# Altium schematic sheet Arriquitaun.SchDoc(Arriquitaun)
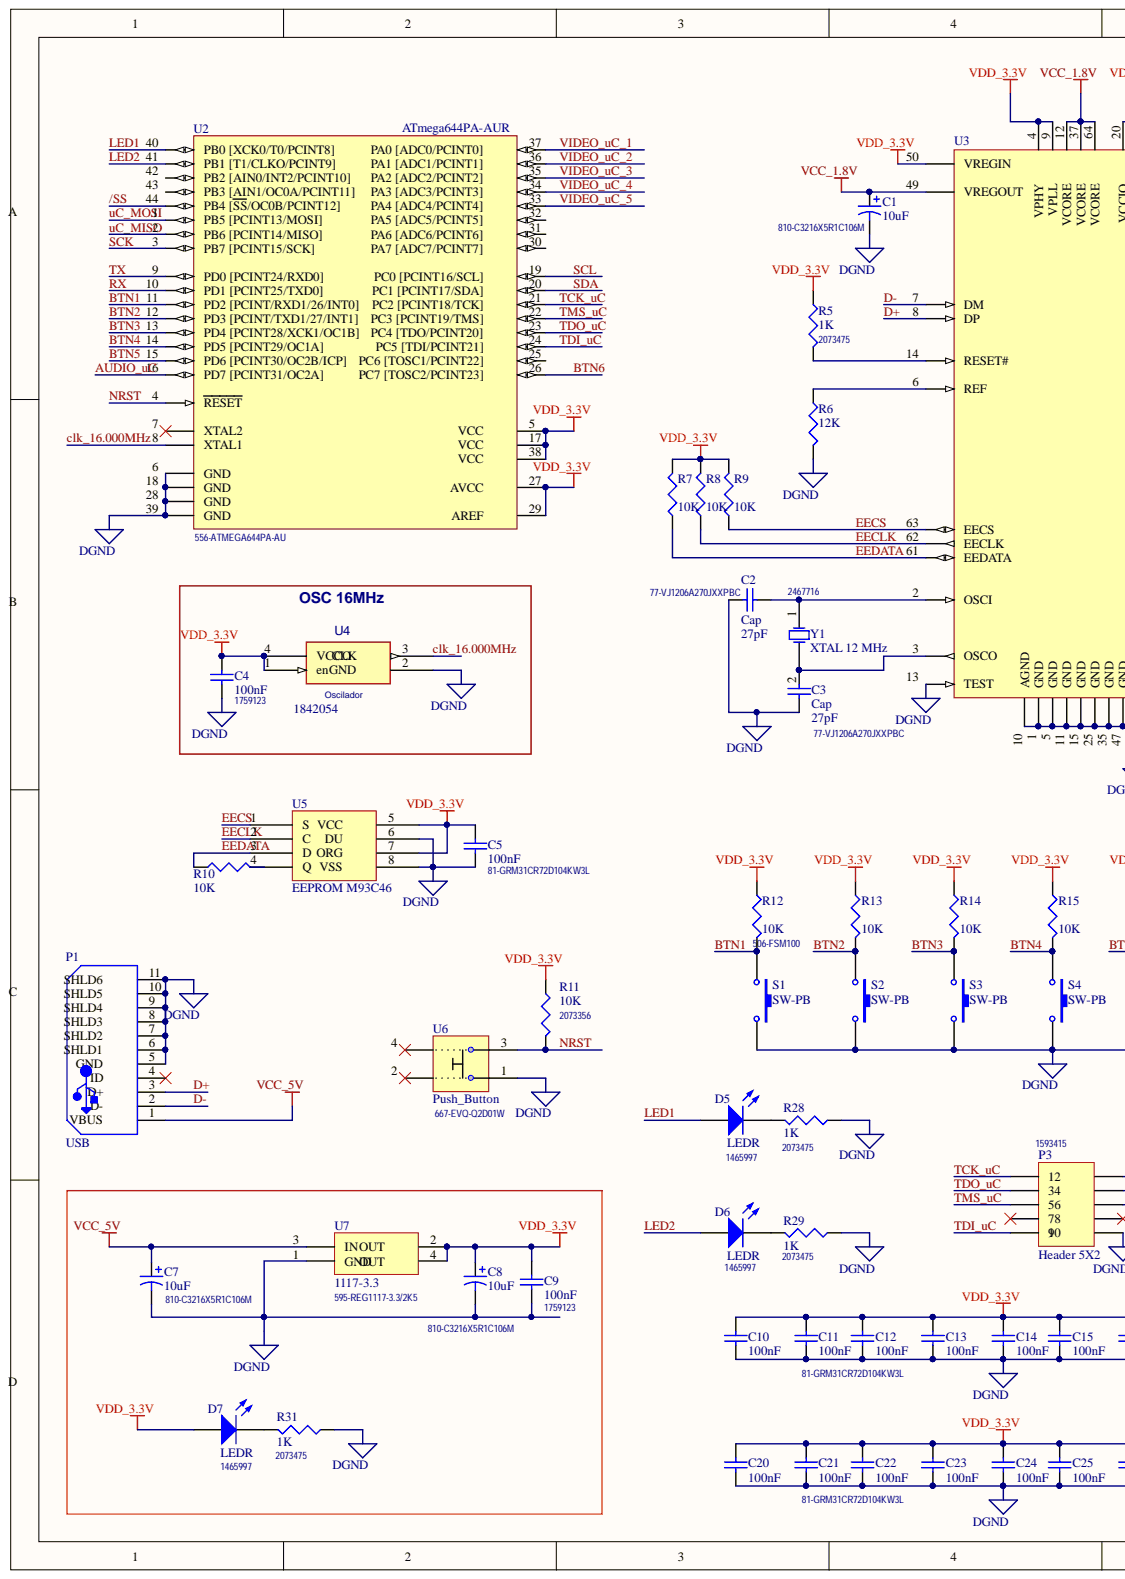
[diagram p0: Arriquitaun.SchDoc, left]
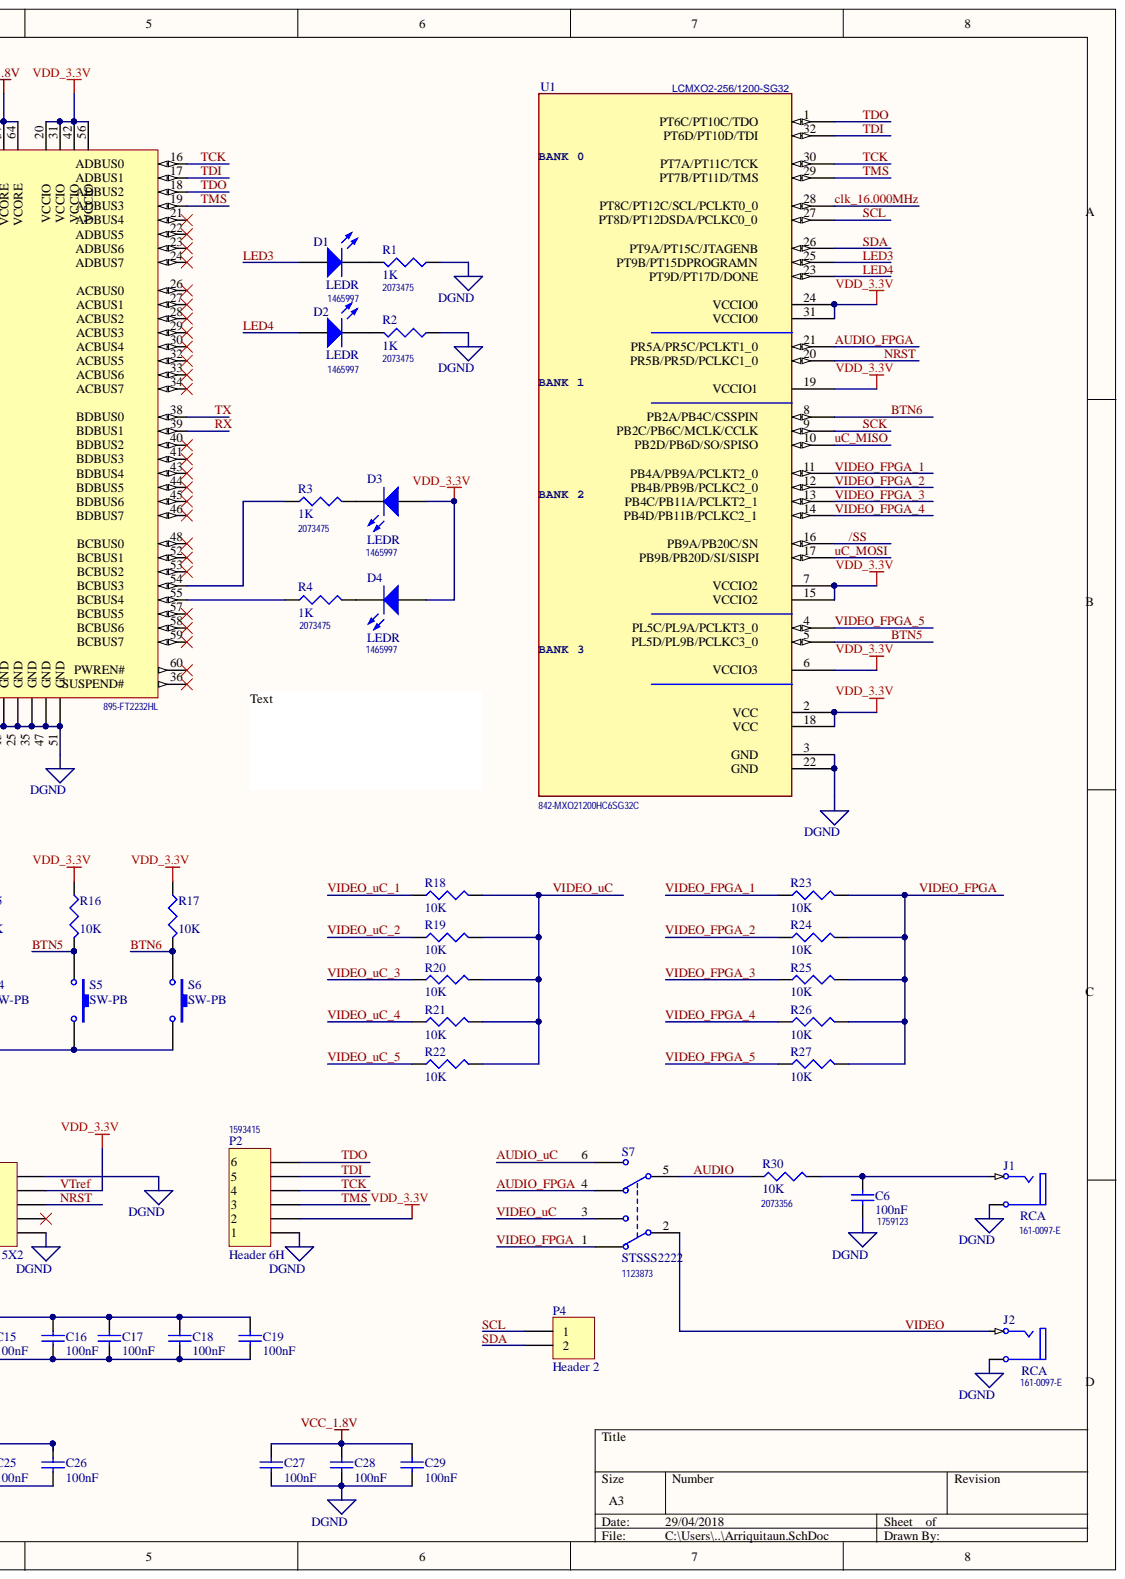
[diagram p1: Arriquitaun.SchDoc, right]

## Parts
C1  value 10uF  Supplier part number 1: 810-C3216X5R1C106M  [C1206]  (hidden comment: Cap Pol1)
C2  Cap  value 27pF  Supplier Part Number 1: 77-VJ1206A270JXXPBC  [C1206]
C3  Cap  value 27pF  Supplier Part Number 1: 77-VJ1206A270JXXPBC  [C1206]
C4  value 100nF  Supplier part number 1: 1759123  [C1206]  (hidden comment: Cap Semi)
C5  value 100nF  Supplier Part Number 1: 81-GRM31CR72D104KW3L  [C1206]  (hidden comment: Cap Semi)
C6  value 100nF  Supplier part number 1: 1759123  [C1206]  (hidden comment: Cap Semi)
C7  value 10uF  Supplier Part Number 1: 810-C3216X5R1C106M  [C1206]  (hidden comment: Cap Pol1)
C8  value 10uF  Supplier part number 1: 810-C3216X5R1C106M  [C1206]  (hidden comment: Cap Pol1)
C9  value 100nF  Supplier part number 1: 1759123  [C1206]  (hidden comment: Cap Semi)
C10  value 100nF  [C1206]  (hidden comment: Cap Semi)
C11  value 100nF  Supplier Part Number 1: 81-GRM31CR72D104KW3L  [C1206]  (hidden comment: Cap Semi)
C12  value 100nF  Supplier Part Number 1: 81-GRM31CR72D104KW3L  [C1206]  (hidden comment: Cap Semi)
C13  value 100nF  [C1206]  (hidden comment: Cap Semi)
C14  value 100nF  [C1206]  (hidden comment: Cap Semi)
C15  value 100nF  [C1206]  (hidden comment: Cap Semi)
C16  value 100nF  [C1206]  (hidden comment: Cap Semi)
C17  value 100nF  [C1206]  (hidden comment: Cap Semi)
C18  value 100nF  [C1206]  (hidden comment: Cap Semi)
C19  value 100nF  [C1206]  (hidden comment: Cap Semi)
C20  value 100nF  [C1206]  (hidden comment: Cap Semi)
C21  value 100nF  Supplier Part Number 1: 81-GRM31CR72D104KW3L  [C1206]  (hidden comment: Cap Semi)
C22  value 100nF  Supplier Part Number 1: 81-GRM31CR72D104KW3L  [C1206]  (hidden comment: Cap Semi)
C23  value 100nF  [C1206]  (hidden comment: Cap Semi)
C24  value 100nF  [C1206]  (hidden comment: Cap Semi)
C25  value 100nF  [C1206]  (hidden comment: Cap Semi)
C26  value 100nF  [C1206]  (hidden comment: Cap Semi)
C27  value 100nF  [C1206]  (hidden comment: Cap Semi)
C28  value 100nF  [C1206]  (hidden comment: Cap Semi)
C29  value 100nF  [C1206]  (hidden comment: Cap Semi)
D1  LEDR  [1206 - LED]
D2  LEDR  Supplier Part Number 1: 1465997  [1206 - LED]
D3  LEDR  Supplier Part Number 1: 1465997  [1206 - LED]
D4  LEDR  Supplier Part Number 1: 1465997  [1206 - LED]
D5  LEDR  Supplier Part Number 1: 1465997  [1206 - LED]
D6  LEDR  Supplier Part Number 1: 1465997  [1206 - LED]
D7  LEDR  Supplier Part Number 1: 1465997  [1206 - LED]
J1  RCA  Supplier Part Number 1: 161-0097-E  [161]
J2  RCA  Supplier Part Number 1: 161-0097-E  [161]
P1  USB  [USB-MICRO-B_V]
P2  Header 6H  Supplier Part Number 1: 1593415  [HDR1X6H]
P3  Header 5X2  Supplier Part Number 1: 1593415  [HDR2X5]
P4  Header 2  [HDR1X2]
R1  value 1K  Supplier Part Number 1: 2073475  [c1206]  (hidden comment: Res3)
R2  value 1K  Supplier Part Number 1: 2073475  [c1206]  (hidden comment: Res3)
R3  value 1K  Supplier Part Number 1: 2073475  [c1206]  (hidden comment: Res3)
R4  value 1K  Supplier Part Number 1: 2073475  [c1206]  (hidden comment: Res3)
R5  value 1K  Supplier Part Number 1: 2073475  [c1206]  (hidden comment: Res3)
R6  value 12K  [c1206]  (hidden comment: Res3)
R7  value 10K  [c1206]  (hidden comment: Res3)
R8  value 10K  [c1206]  (hidden comment: Res3)
R9  value 10K  [c1206]  (hidden comment: Res3)
R10  value 10K  [c1206]  (hidden comment: Res3)
R11  value 10K  Supplier Part Number 1: 2073356  [c1206]  (hidden comment: Res3)
R12  value 10K  [c1206]  (hidden comment: Res3)
R13  value 10K  [c1206]  (hidden comment: Res3)
R14  value 10K  [c1206]  (hidden comment: Res3)
R15  value 10K  [c1206]  (hidden comment: Res3)
R16  value 10K  [c1206]  (hidden comment: Res3)
R17  value 10K  [c1206]  (hidden comment: Res3)
R18  value 10K  [c1206]  (hidden comment: Res3)
R19  value 10K  [c1206]  (hidden comment: Res3)
R20  value 10K  [c1206]  (hidden comment: Res3)
R21  value 10K  [c1206]  (hidden comment: Res3)
R22  value 10K  [c1206]  (hidden comment: Res3)
R23  value 10K  [c1206]  (hidden comment: Res3)
R24  value 10K  [c1206]  (hidden comment: Res3)
R25  value 10K  [c1206]  (hidden comment: Res3)
R26  value 10K  [c1206]  (hidden comment: Res3)
R27  value 10K  [c1206]  (hidden comment: Res3)
R28  value 1K  Supplier Part Number 1: 2073475  [c1206]  (hidden comment: Res3)
R29  value 1K  Supplier Part Number 1: 2073475  [c1206]  (hidden comment: Res3)
R30  value 10K  Supplier Part Number 1: 2073356  [c1206]  (hidden comment: Res3)
R31  value 1K  Supplier Part Number 1: 2073475  [c1206]  (hidden comment: Res3)
S1  SW-PB  Supplier Part Number 1: 506-FSM100  [FSM100]
S2  SW-PB  [FSM100]
S3  SW-PB  [FSM100]
S4  SW-PB  [FSM100]
S5  SW-PB  [FSM100]
S6  SW-PB  [FSM100]
S7  STSSS2222  Supplier Part Number 1: 1123873  [SWITCH]
U1  LCMXO2-256/1200-SG32  Supplier Part Number 1: 842-MXO21200HC6SG32C  [QFN32 5x5mm SOT617-3]
U2  ATmega644PA-AUR  Supplier Part Number 1: 556-ATMEGA644PA-AU  [44A_N]
U3  Supplier Part Number 1: 895-FT2232HL  [LQFP-64_N]  (hidden comment: FT2232HL-Tray)
U4  Oscilador  Supplier Part Number 1: 1842054  [OSCILADOR]
U5  EEPROM M93C46
U6  Push_Button  Supplier Part Number 1: 667-EVQ-Q2D01W  [PUSHBUTTON]
U7  1117-3.3  Supplier Part Number 1: 595-REG1117-3.3/2K5  [ZZ311]
Y1  XTAL 12 MHz  Supplier Part Number 1: 2467716  [CRYSTAL_HC49-US-SM]

## Nets
DGND: C1.2 C2.2 C3.1 C4.1 C5.1 C6.1 C7.2 C8.2 C9.1 C10.1 C11.1 C12.1 C13.1 C14.1 C15.1 C16.1 C17.1 C18.1 C19.1 C20.1 C21.1 C22.1 C23.1 C24.1 C25.1 C26.1 C27.1 C28.1 C29.1 J1.1A J2.1A P1.5 P1.6 P1.7 P1.8 P1.9 P1.10 P1.11 P2.1 P3.2 P3.10 R1.1 R2.1 R6.2 R28.1 R29.1 R31.1 S1.2 S2.2 S3.2 S4.2 S5.2 S6.2 U1.3 U1.22 U2.6(GND) U2.18(GND) U2.28(GND) U2.39(GND) U3.1(GND) U3.5(GND) U3.10(AGND) U3.11(GND) U3.13(TEST) U3.15(GND) U3.25(GND) U3.35(GND) U3.47(GND) U3.51(GND) U4.2 U5.6 U5.8 U6.1 U7.1(GND)
VCC_1.8V: C1.1 C27.2 C28.2 C29.2 U3.12 U3.37 U3.49(VREGOUT) U3.64
VCC_5V: C7.1 P1.1 U7.3(IN_OUT)
/SS: U1.16 U2.44(PB4_[SS/OC0B/PCINT12])
AUDIO: R30.1 S7.5
AUDIO_FPGA: S7.4 U1.21
AUDIO_UC: S7.6 U2.16(PD7_[PCINT31/OC2A])
BTN1: R12.2 S1.1 U2.11(PD2_[PCINT/RXD1/26/INT0])
BTN2: R13.2 S2.1 U2.12(PD3_[PCINT/TXD1/27/INT1])
BTN3: R14.2 S3.1 U2.13(PD4_[PCINT28/XCK1/OC1B])
BTN4: R15.2 S4.1 U2.14(PD5_[PCINT29/OC1A])
BTN5: R16.2 S5.1 U1.5 U2.15(PD6_[PCINT30/OC2B/ICP])
BTN6: R17.2 S6.1 U1.8 U2.26
CLK_16.000MHZ: U1.28 U2.8(XTAL1) U4.3
D+: P1.3 U3.8(DP)
D-: P1.2 U3.7(DM)
EECLK: R8.1 U3.62(EECLK) U5.2(C)
EECS: R9.1 U3.63(EECS) U5.1(S)
EEDATA: R7.1 R10.1 U3.61(EEDATA) U5.3(D)
LED1: D5.1 U2.40(PB0_[XCK0/T0/PCINT8])
LED2: D6.1 U2.41(PB1_[T1/CLKO/PCINT9])
LED3: D1.1 U1.25
LED4: D2.1 U1.23
NRST: P3.6 R11.2 U1.20 U2.4(RESET) U6.3
RX: U2.10(PD1_[PCINT25/TXD0]) U3.39
SCK: U1.9 U2.3(PB7_[PCINT15/SCK])
SCL: P4.1 U1.27 U2.19
SDA: P4.2 U1.26 U2.20
TCK: P2.4 U1.30 U3.16
TCK_UC: P3.1 U2.21
TDI: P2.5 U1.32 U3.17
TDI_UC: P3.9 U2.24
TDO: P2.6 U1.1 U3.18
TDO_UC: P3.3 U2.23
TMS: P2.3 U1.29 U3.19
TMS_UC: P3.5 U2.22
TX: U2.9(PD0_[PCINT24/RXD0]) U3.38
UC_MISO: U1.10 U2.2(PB6_[PCINT14/MISO])
UC_MOSI: U1.17 U2.1(PB5_[PCINT13/MOSI])
VIDEO: J2.2B S7.2
VIDEO_FPGA: R23.2 R24.2 R25.2 R26.2 R27.2 S7.1
VIDEO_FPGA_1: R23.1 U1.11
VIDEO_FPGA_2: R24.1 U1.12
VIDEO_FPGA_3: R25.1 U1.13
VIDEO_FPGA_4: R26.1 U1.14
VIDEO_FPGA_5: R27.1 U1.4
VIDEO_UC: R18.2 R19.2 R20.2 R21.2 R22.2 S7.3
VIDEO_UC_1: R18.1 U2.37
VIDEO_UC_2: R19.1 U2.36
VIDEO_UC_3: R20.1 U2.35
VIDEO_UC_4: R21.1 U2.34
VIDEO_UC_5: R22.1 U2.33
VTREF: C4.2 C5.2 C8.1 C9.2 C10.2 C11.2 C12.2 C13.2 C14.2 C15.2 C16.2 C17.2 C18.2 C19.2 C20.2 C21.2 C22.2 C23.2 C24.2 C25.2 C26.2 D3.1 D4.1 D7.1 P2.2 P3.4 R5.1 R7.2 R8.2 R9.2 R11.1 R12.1 R13.1 R14.1 R15.1 R16.1 R17.1 U1.2 U1.6 U1.7 U1.15 U1.18 U1.19 U1.24 U1.31 U2.5 U2.17 U2.27 U2.29 U2.38 U3.4 U3.9 U3.20 U3.31 U3.42 U3.50(VREGIN) U3.56 U4.1(en_GND) U4.4(VCCO) U5.5 U5.7 U7.2 U7.4
NetC2_1: C2.1 U3.2(OSCI) Y1.1
NetC3_2: C3.2 U3.3(OSCO) Y1.2
NetC6_2: C6.2 J1.2B R30.2
NetD1_2: D1.2 R1.2
NetD2_2: D2.2 R2.2
NetD3_2: D3.2 R3.2
NetD4_2: D4.2 R4.2
NetD5_2: D5.2 R28.2
NetD6_2: D6.2 R29.2
NetD7_2: D7.2 R31.2
NetR3_1: R3.1 U3.54
NetR4_1: R4.1 U3.55
NetR5_2: R5.2 U3.14(RESET#)
NetR6_1: R6.1 U3.6(REF)
NetR10_2: R10.2 U5.4(Q)

Not connected: P1 4; P3 7 8; U2 7(XTAL2) 25 30 31 32 42(PB2_[AIN0/INT2/PCINT10]) 43(PB3_[AIN1/OC0A/PCINT11]); U3 21 22 23 24 26 27 28 29 30 32 33 34 36 40 41 43 44 45 46 48 52 53 57 58 59 60; U6 2 4

## Library data of the parts
C1 C7 C8 = Cap Pol1: Polarized Capacitor (Radial); Component Kind: Standard; LatestRevisionDate: 17-Jul-2002; LatestRevisionNote: Re-released for DXP Platform.; PackageDescription: Polarized Capacitor (Radial); 2 Leads; PackageReference: RB7.6-15; Published: 8-Jun-2000; Signal Integrity: Cap Pol; Simulation: CAP; Supplier 1: Mouser; Library Name: DCRC_Remote.SCHLIB; Publisher: Altium Limited
  C7: Supplier part number 1: 810-C3216X5R1C106M
C2 C3 = Cap: Capacitor; Component Kind: Standard; LatestRevisionDate: 17-Jul-2002; LatestRevisionNote: Re-released for DXP Platform.; Library Name: Miscellaneous Devices.IntLib; PackageDescription: Radial Cap, Thru-Hole; 2 Leads; 0.3 in Pin Spacing; PackageReference: RAD-0.3; Published: 8-Jun-2000; Signal Integrity: Cap; Simulation: CAP; Supplier 1: Mouser; Publisher: Altium Limited
C4-C6 C9-C29 = Cap Semi: Capacitor (Semiconductor SIM Model); Component Kind: Standard; LatestRevisionDate: 29-May-2009; LatestRevisionNote: IPC-7351 Footprint Added.; PackageDescription: Chip Capacitor; PackageReference: C1206; Published: 8-Jun-2000; Signal Integrity: Cap Semi; Simulation: CAP; Library Name: DCRC_Remote.SCHLIB; Publisher: Altium Limited
  C4 C6 C9: Supplier 1: Farnell
  C5 C11 C12 C21 C22: Supplier 1: Mouser
  C10 C13-C20 C23-C29: Supplier 1: Mouser; Supplier Part Number 1: 81-GRM31CR72D104KW3L
D1-D7 = LED2: Typical RED, GREEN, YELLOW, AMBER GaAs LED; Component Kind: Standard; LatestRevisionDate: 17-Jul-2002; LatestRevisionNote: Re-released for DXP Platform.; PackageDescription: SM LED; 2 Flat Leads; PackageReference: 3.2X1.6X1.1; PackageVersion: Feb-2002; Published: 8-Jun-2000; Simulation: LED2; Supplier 1: Farnell; Library Name: DCRC_Remote.SCHLIB; Publisher: Altium Limited
  D1: Supplier Part Number 1: 1465997
J1 J2 = RCA: RCA Phono Jack, Right Angle, Thru-Hole, Snap-In; DrillAndSlotSize: Corresponds to nominal value.; PCB_Layout: Complies with manufacturer's recommendation.; Component Kind: Standard; ComponentLink1Description: Manufacturer Link; ComponentLink1URL: http://www.mouser.com/kobiconn/; DatasheetDocument: 7-Jul-2000; LatestRevisionDate: 15-Jul-2004; LatestRevisionNote: Component Replaced.; Library Name: Miscellaneous Connectors.IntLib; PackageDocument: 7-Jul-2000; PackageReference: 161; Published: 15-Jul-2004; Signal Integrity: RCA; Supplier 1: Mouser; Publisher: Altium Limited
P2 = Header 6H: Header, 6-Pin, Right Angle; Component Kind: Standard; LatestRevisionDate: 17-Jul-2002; LatestRevisionNote: Re-released for DXP Platform.; Library Name: Miscellaneous Connectors.IntLib; Signal Integrity: Connector; Supplier 1: Farnell; Publisher: Altium Limited
P3 = Header 5X2: Header, 5-Pin, Dual row; Component Kind: Standard; LatestRevisionDate: 17-Jul-2002; LatestRevisionNote: Re-released for DXP Platform.; Library Name: Miscellaneous Connectors.IntLib; Signal Integrity: Connector; Supplier 1: Farnell; Publisher: Altium Limited
P4 = Header 2: Header, 2-Pin; Component Kind: Standard; LatestRevisionDate: 17-Jul-2002; LatestRevisionNote: Re-released for DXP Platform.; Library Name: Miscellaneous Connectors.IntLib; Signal Integrity: Connector; Publisher: Altium Limited
R1-R31 = Res3: Resistor; Component Kind: Standard; LatestRevisionDate: 29-May-2009; LatestRevisionNote: IPC-7351 Footprint Added.; PackageDescription: Chip Resistor; PackageReference: J1-0603; Published: 8-Jun-2000; Signal Integrity: Res; Simulation: RESISTOR; Publisher: Altium Limited
  R1-R6 R11 R28-R31: Supplier 1: Farnell; Library Name: DCRC_Remote.SCHLIB
  R7-R10 R12-R27: Library Name: Miscellaneous Devices.IntLib
S1-S6 = SW-PB: Switch; Component Kind: Standard; LatestRevisionDate: 17-Jul-2002; LatestRevisionNote: Re-released for DXP Platform.; Library Name: Miscellaneous Devices.IntLib; PackageDescription: Switch; 2 Leads; PackageReference: SPST-2; Published: 24-Mar-1999; Supplier 1: Mouser; Publisher: Altium Limited
  S2-S6: Supplier Part Number 1: 506-FSM100
S7 = Switch: Double switch STSSS2222; Component Kind: Standard; Supplier 1: Farnell; Library Name: CEIduino.SchLib
U2 = ATmega644PA-AUR: 8-bit AVR Microcontroller, 64KB Flash, 2KB EEPROM, 4KB SRAM, 44-pin TQFP, Industrial Grade (-40°C to 85°C), Reel; Component Kind: Standard; ComponentLink1Description: Manufacturer Link; ComponentLink1URL: http://www.atmel.com/products/avr/default.asp?; ComponentLink2Description: ATmega164A/164PA/324A/324PA/644A/644PA/1284/1284P Datasheet; ComponentLink2URL: http://www.atmel.com/dyn/resources/prod_documents/doc8272.pdf; ComponentLink3Description: ATmega164A/164PA/324A/324PA/644A/644PA/1284/1284P Summary; ComponentLink3URL: http://www.atmel.com/dyn/resources/prod_documents/8272S.pdf; ComponentLink4Description: Atmel.com Home; ComponentLink4URL: http://www.atmel.com; DatasheetVersion: revA, Jan-2010; Library Name: Atmel Microcontroller 8-Bit megaAVR.IntLib; PackageDescription: 44-Pin Plastic Thin Profile Quad Flat Pack, 10 x 10 x 1.0 mm Body, 0.8 mm Pitch (TQFP); PackageReference: 44A; PackageVersion: rev.B, Oct-2001; Published: 1-Apr-2010; Supplier 1: Mouser; Publisher: Altium Limited
U3 = FT2232HL-Tray: Dual High Speed USB To Multipurpose UART/FIFO IC, 64-pin LQFP, Tray; Manufacturer: FTDI; Component Kind: Standard; ComponentLink1Description: Manufacturer URL; ComponentLink1URL: http://www.ftdichip.com/; ComponentLink2Description: Datasheet; ComponentLink2URL: http://www.ftdichip.com/Support/Documents/DataSheets/ICs/DS_FT2232H.pdf; DatasheetVersion: ver 2.21, Jun-2012; Library Name: FTDI FT Series.IntLib; PackageDescription: 64-Pin Thin Quad Flat Pack, 10 x 10 x 1.4 mm Body, 0.5 mm Pitch; PackageReference: LQFP-64; PackageVersion: Jun-12; PartNumber: FT2232HL-Tray; Supplier 1: Mouser
U6 = Push_Button: Button SMD; Component Kind: Standard; Supplier 1: Mouser; Library Name: DCRC_Base.SCHLIB
U7 = REG1117-3.3: 800mA and 1A Low Dropout Positive Regulator 2.85V, 3.3V, 5V, and Adjustable; Component Kind: Standard; ComponentLink1Description: Manufacturer Link; ComponentLink1URL: http://www.ti.com/; ComponentLink2Description: Datasheet; ComponentLink2URL: http://www-s.ti.com/sc/ds/REG1117.pdf; DatasheetVersion: Sep-1997; LatestRevisionDate: 17-Jul-2002; LatestRevisionNote: Re-released for DXP Platform.; PackageDescription: SOT-223/SC-73; 4 Leads; Body 6.7 x 7.3 mm, inc. leads (LxW max); PackageReference: ZZ311; PackageVersion: revC; Published: 8-Jun-2000; Supplier 1: Mouser; Library Name: DCRC_Base.SCHLIB; Publisher: Altium Limited
Y1 = XTAL: Crystal Oscillator; Component Kind: Standard; LatestRevisionDate: 17-Jul-2002; LatestRevisionNote: Re-released for DXP Platform.; Library Name: Miscellaneous Devices.IntLib; PackageDescription: Crystal, Thru-Hole; 2 Leads; Body 3.1 x 8.2 mm (Dia.xH); PackageReference: R38; Published: 8-Jun-2000; Simulation: XTAL; Supplier 1: Farnell; Publisher: Altium Limited
P1 = USB: Component Kind: Standard; Library Name: Arriquitaun.SchLib
U1 = LCMXO2-256/1200-SG32: Component Kind: Standard; Supplier 1: Mouser; Library Name: LATTICEPLDS_Library.SchLib
U4 = Oscilador: Component Kind: Standard; Supplier 1: Farnell; Library Name: Arriquitaun.SchLib
U5 = EEPROM M93C46: Component Kind: Standard; Library Name: Arriquitaun.SchLib
